# Altium schematic sheet PowerCastle.SchDoc
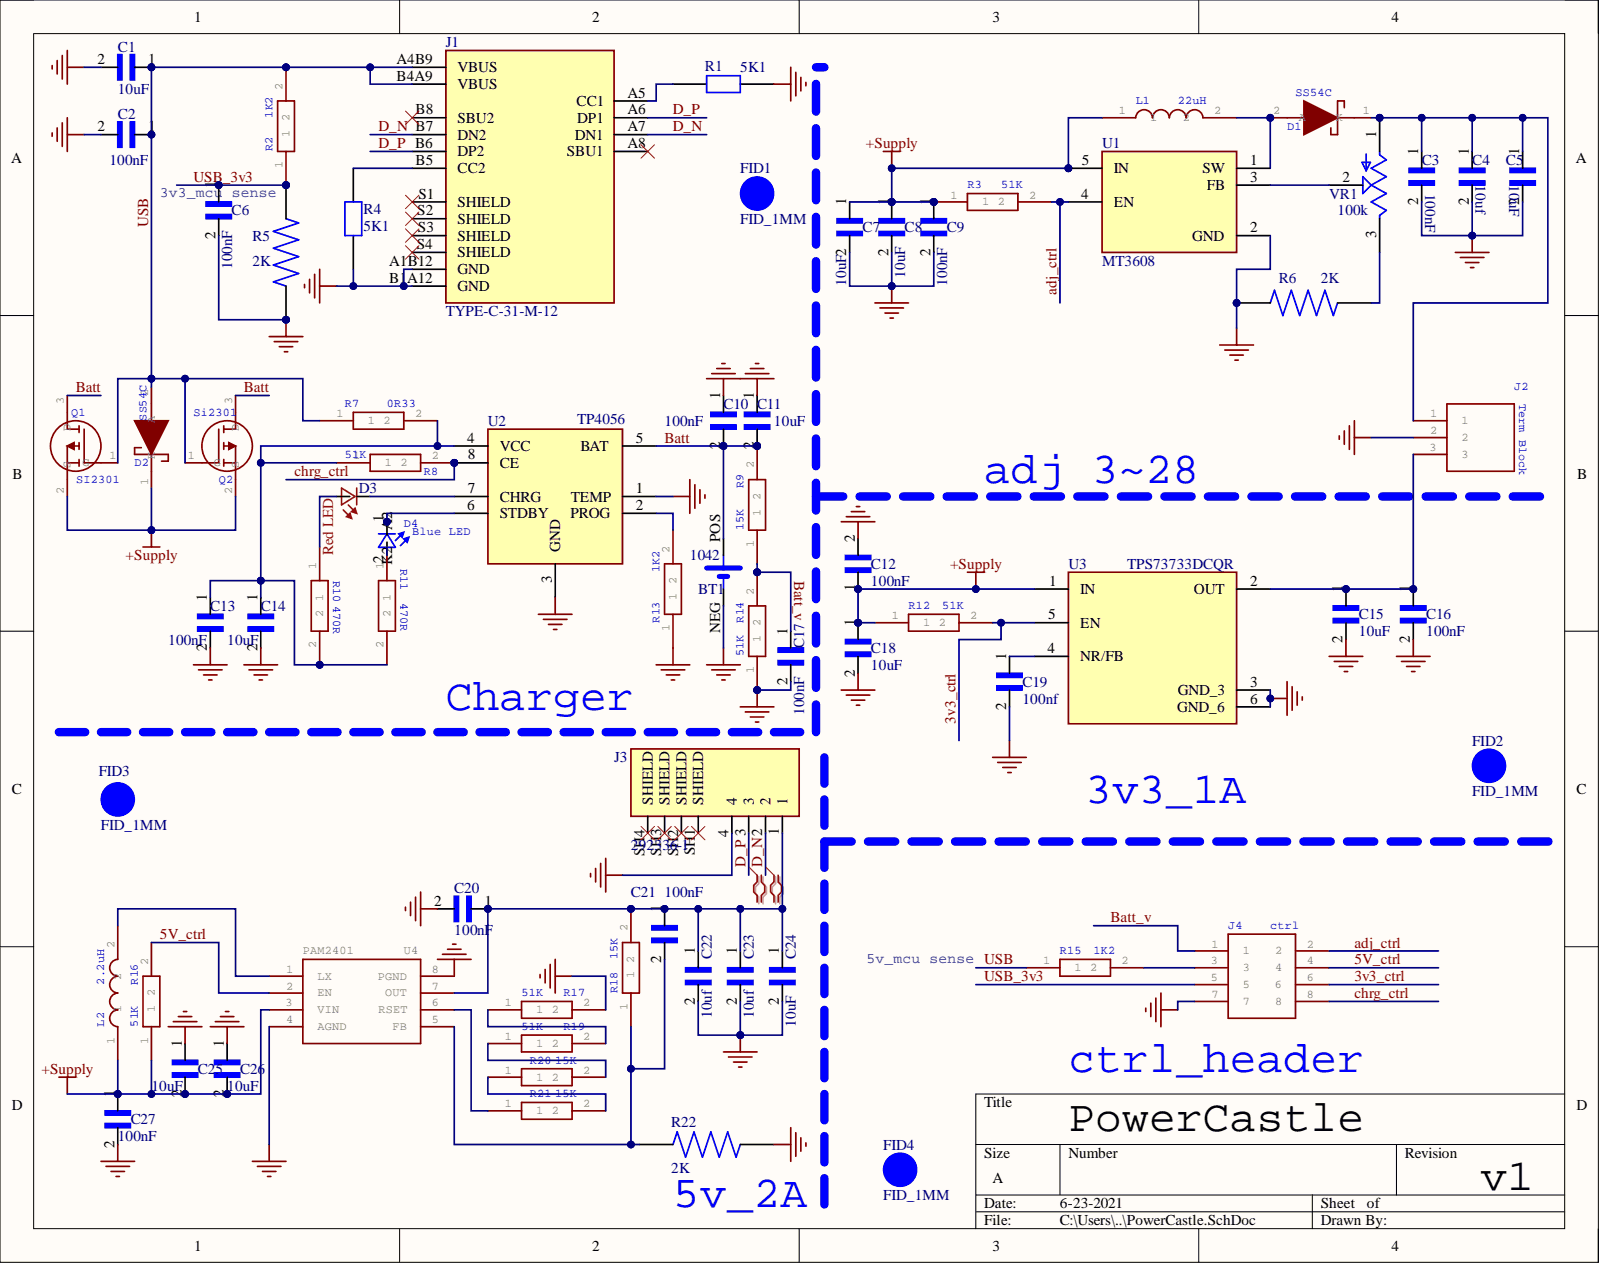
[diagram p0: PowerCastle.SchDoc]

## Parts
BT1  1042  [BAT_1042]
C1  10uF  [CAPC1608X90N]
C2  100nF  [CAPC1005X55N]
C3  100nF  [CAPC1005X55N]
C4  10uf  [CAPC1608X90N]
C5  10uF  [CAPC1608X90N]
C6  100nF  [CAPC1005X55N]
C7  10uF  [CAPC1608X90N]
C8  10uF  [CAPC1608X90N]
C9  100nF  [CAPC1005X55N]
C10  100nF  [CAPC1005X55N]
C11  10uF  [CAPC1608X90N]
C12  100nF  [CAPC1005X55N]
C13  100nF  [CAPC1005X55N]
C14  10uF  [CAPC1608X90N]
C15  10uF  [CAPC1608X90N]
C16  100nF  [CAPC1005X55N]
C17  100nF  [CAPC1005X55N]
C18  10uF  [CAPC1608X90N]
C19  100nf  [CAPC1005X55N]
C20  100nF  [CAPC1005X55N]
C21  100nF  [CAPC1005X55N]
C22  10uf  [CAPC1608X90N]
C23  10uf  [CAPC1608X90N]
C24  10uF  [CAPC1608X90N]
C25  10uF  [CAPC1608X90N]
C26  10uF  [CAPC1608X90N]
C27  100nF  [CAPC1005X55N]
D1  SS54C  [DIOM7859X239N]
D2  SS54C  [DIOM7859X239N]
D3  Red LED  [0603]
D4  Blue LED  [19217BHCZL1M2RY3T]
FID1  FID_1MM  [FID_40MM]  (no BOM)
FID2  FID_1MM  [FID_40MM]  (no BOM)
FID3  FID_1MM  [FID_40MM]  (no BOM)
FID4  FID_1MM  [FID_40MM]  (no BOM)
J1  TYPE-C-31-M-12  [HRO_TYPE-C-31-M-12]
J2  Term Block  [1711738]
J3  292336-1  [TE_292336-1]
J4  ctrl  [RHDR8W64P254_2X4_1066X500X875P]
L1  22uH  [INDPM125125X685N]
L2  2.2uH  [SLF6045T2R2N3R33PF]
Q1  SI2301  [SOT95P240X120-3N]
Q2  Si2301  [SOT95P240X120-3N]
R1  5K1  [RESC1005X40N - duplicate]
R2  1K2  [RESC1608X55N 1K2]
R3  51K  [RESC1608X55N - 51k]
R4  5K1  [RESC1005X40N - duplicate]
R5  2K  [RESC1005X40N]
R6  2K  [RESC1005X40N]
R7  0R33  [RESC3126X65N]
R8  51K  [RESC1608X55N - 51k]
R9  15K  [RESC1608X55N 15k]
R10  470R  [RESC1005X40N]
R11  470R  [RESC1005X40N]
R12  51K  [RESC1608X55N - 51k]
R13  1K2  [RESC1608X55N 1K2]
R14  51K  [RESC1608X55N - 51k]
R15  1K2  [RESC1608X55N 1K2]
R16  51K  [RESC1608X55N - 51k]
R17  51K  [RESC1608X55N - 51k]
R18  15K  [RESC1608X55N 15k]
R19  51K  [RESC1608X55N - 51k]
R20  15K  [RESC1608X55N 15k]
R21  15K  [RESC1608X55N 15k]
R22  2K  [RESC1005X40N]
U1  MT3608  [SOT95P280X145-6N]
U2  TP4056  [TP4056]
U3  TPS73733DCQR  [IC_TPS73733DCQR]
U4  PAM2401  [SOP65P490X110-8N]
VR1  100k  [TRIM_3362P-1-104]

## Nets
+Supply: C7.1 C8.1 C9.1 C12.1 C18.1 C25.2 C26.2 C27.1 D2.1 L1.1 L2.1 Q1.2 Q2.2 R3.1 R12.1 R16.1 U1.5(IN) U3.1(IN) U4.3(VIN)
GND: BT1.NEG C1.2 C2.2 C3.2 C4.2 C5.2 C6.2 C7.2 C8.2 C9.2 C10.1 C11.1 C12.2 C13.2 C14.2 C15.2 C16.2 C17.2 C18.2 C19.2 C20.2 C22.2 C23.2 C24.2 C25.1 C26.1 C27.2 J1.A1B12(GND) J1.B1A12(GND) J2.2 J3.4 J4.7 R1.1 R4.2 R5.1 R6.2 R13.1 R14.1 R17.2 R22.1 U1.2(GND) U2.1(TEMP) U2.3(GND) U3.3(GND_3) U3.6(GND_6) U4.4(AGND) U4.8(PGND)
3v3_ctrl: J4.6 R12.2 U3.5(EN)
5V_ctrl: J4.4 R16.2 U4.2(EN)
adj_ctrl: J4.2 R3.2 U1.4(EN)
Batt: BT1.POS C10.2 C11.2 Q1.3 Q2.3 R9.2 U2.5(BAT)
Batt_v: C17.1 J4.1 R9.1 R14.2
chrg_ctrl: J4.8 R8.2 U2.8(CE)
D_N: J1.A7(DN1) J1.B7(DN2) J3.2
D_P: J1.A6(DP1) J1.B6(DP2) J3.3
USB: C1.1 C2.1 D2.2 J1.A4B9(VBUS) J1.B4A9(VBUS) Q1.1 Q2.1 R2.2 R7.1 R15.1
USB_3v3: C6.1 J4.5 R2.1 R5.2
NetC3_1: C3.1 C4.1 C5.1 D1.1 J2.1 VR1.1
NetC13_1: C13.1 C14.1 R7.2 R8.1 R10.2 R11.2 U2.4(VCC)
NetC15_1: C15.1 C16.1 J2.3 U3.2(OUT)
NetC19_1: C19.1 U3.4(NR/FB)
NetC20_1: C20.1 C21.1 C22.1 C23.1 C24.1 J3.1 R18.2 U4.7(OUT)
NetC21_2: C21.2 R18.1 R22.2 U4.5(FB)
NetD1_2: D1.2 L1.2 U1.1(SW)
NetD3_1: D3.1 U2.7(CHRG)
NetD3_2: D3.2 R10.1
NetD4_1: D4.1 U2.6(STDBY)
NetD4_2: D4.2 R11.1
NetJ1_A5: J1.A5(CC1) R1.2
NetJ1_B5: J1.B5(CC2) R4.1
NetJ4_3: J4.3 R15.2
NetL2_2: L2.2 U4.1(LX)
NetR6_1: R6.1 VR1.3
NetR13_2: R13.2 U2.2(PROG)
NetR17_1: R17.1 R19.2
NetR19_1: R19.1 R20.2
NetR20_1: R20.1 R21.2
NetR21_1: R21.1 U4.6(RSET)
NetU1_3: U1.3(FB) VR1.2

Not connected: J1 A8(SBU1) B8(SBU2) S1(SHIELD) S2(SHIELD) S3(SHIELD) S4(SHIELD); J3 SH1(SHIELD) SH2(SHIELD) SH3(SHIELD) SH4(SHIELD)

## Library data of the parts
BT1 = 1042: RF EMI Absorbing Sheet Q-ZorbÂ® Silicone Rubber Non-Conductive, Single Sided 24.000 _609.60mm_ X 24.000 _609.60mm_ X 0.094 _2.40mm_; MF: Laird Technologies; Availability: Unavailable; Component Kind: Standard; MP: 1042; Package: 0402 Laird Technologies; Price: None; SnapEDA_Link: https://www.snapeda.com/parts/1042/Laird%20Technologies%20EMI/view-part/238870/?ref=snap; Library Name: PowerCastle.SchLib
C1 C4 C5 C7 C8 C11 C14 C15 C18 C22-C26 = 10uf: 10ÂµF Â±10% 10V Ceramic Capacitor X5R 0603 _1608 Metric_; MF: Samsung; MP: CL10A106KP8NNNC; Availability: Unavailable; Component Kind: Standard; LCSC Part #: C19702; Package: 0603 Samsung; Price: None; SnapEDA_Link: https://www.snapeda.com/parts/CL10A106KP8NNNC/Samsung/view-part/3598152/?ref=snap; Library Name: PowerCastle.SchLib
C2 C3 C6 C9 C10 C12 C13 C16 C17 C19-C21 C27 = 100nf: 0.1ÂµF Â±10% 16V Ceramic Capacitor X7R 0402 _1005 Metric_; MF: Samsung Electro-Mechanics; MP: CL05B104KO5NNNC; Availability: Unavailable; Component Kind: Standard; LCSC Part #: C1525; Package: 0402 Samsung; Price: None; SnapEDA_Link: https://www.snapeda.com/parts/CL05B104KO5NNNC/Samsung%20Electro-Mechanics/view-part/3598311/?ref=snap; Library Name: PowerCastle.SchLib
D1 D2 = SS54C-HF: Manufacturer_Name: Comchip Technology; GateName_1: G$1; Component Kind: Standard; DeviceSetName: SS54C-HF; Height: 2.39mm; LCSC Part #: C16103; LibraryName: SS54C-HF; Manufacturer_Part_Number: SS54C-HF; Mouser_Part_Number: 750-SS54C-HF; Mouser_Price-Stock: https://www.mouser.co.uk/ProductDetail/Comchip-Technology/SS54C-HF?qs=GBLSl2AkirvDP3hY2YYGnA%3D%3D; Package: DIOM7859X239N; SymbolName_1: SS54C-HF; Library Name: PowerCastle.SchLib
D3 = Red LED: Red 631nm LED Indication - Discrete 2V 0603 _1608 Metric_; MF: Lite-On Inc.; MP: LTST-C191KRKT; Parameter 1: C125099; Component Kind: Standard; Digi-Key_Part_Number: 160-1447-1-ND; Digi-Key_Purchase_URL: https://www.digikey.in/product-detail/en/lite-on-inc/LTST-C191KRKT/160-1447-1-ND/386836?utm_source=snapeda&utm_medium=aggregator&utm_campaign=symbol; Package: 0603 Lite-On; SnapEDA_Link: https://www.snapeda.com/parts/LTST-C191KRKT/Lite-On%20Inc./view-part/234319/?ref=snap; Library Name: PowerCastle.SchLib
D4 = Blue_LED: Manufacturer_Name: Everlight; Manufacturer_Part_Number: 19-217/BHC-ZL1M2RY/3T; DeviceSetName: 19-217_BHC-ZL1M2RY_3T; GateName_1: G$1; Component Kind: Standard; Height: 0.45mm; LCSC Part #: C72041; LibraryName: 19-217_BHC-ZL1M2RY_3T; Package: 19217BHCZL1M2RY3T; SymbolName_1: 19-217_BHC-ZL1M2RY_3T; Library Name: PowerCastle.SchLib
FID1-FID4 = FID_1MM: Fiducial 40mm diameter Mask; Library Name: PowerCastle.SchLib
J1 = TYPE-C-31-M-12: USB Connectors 24 Receptacle 1 8.94*7.3mm RoHS; MF: HRO Electronics Co., Ltd.; Availability: Unavailable; Component Kind: Standard; MP: TYPE-C-31-M-12; Package: Package; Price: None; SnapEDA_Link: https://www.snapeda.com/parts/TYPE-C-31-M-12/HRO%20Electronics%20Co.,%20Ltd./view-part/3228496/?ref=snap; Library Name: PowerCastle.SchLib
J2 = 1711738: Manufacturer_Name: Phoenix Contact; GateName_1: G$1; Arrow_Part_Number: 1711738; Arrow_Price-Stock: https://www.arrow.com/en/products/1711738/phoenix-contact; Component Kind: Standard; DeviceSetName: 1711738; Height: 18.2mm; LCSC Part #: C91156; LibraryName: 1711738; Manufacturer_Part_Number: 1711738; Mouser_Part_Number: 651-1711738; Mouser_Price-Stock: https://www.mouser.co.uk/ProductDetail/Phoenix-Contact/1711738?qs=F79NelsxgYGJoCQSSUE92w%3D%3D; Package: 1711738; SymbolName_1: 1711738; Library Name: PowerCastle.SchLib
J3 = 292336-1: Connector USB Receptacle 4 Position 2mm Solder Right Angle Thru-Hole 4 Terminal 1 Port Tube; MF: TE Connectivity; Availability: Unavailable; Component Kind: Standard; DigiKey-Purchase-URL: https://snapeda.com/shop?store=DigiKey&id=2759097; LCSC Part #: C305979; MP: 292336-1; Package: None; Price: None; SnapEDA_Link: https://www.snapeda.com/parts/292336-1/TE%20Connectivity%20AMP%20Connectors/view-part/2759097/?ref=snap; Library Name: PowerCastle.SchLib
J4 = 8POS 2mm54: A2541HWV-2X4P; Manufacturer_Name: Changjiang Connectors; GateName_1: G$1; Component Kind: Standard; DeviceSetName: A2541HWV-2X4P; Height: 8.75mm; LCSC Part #: C239344; LibraryName: A2541HWV-2x4P; Manufacturer_Part_Number: A2541HWV-2x4P; Package: RHDR8W64P254_2X4_1066X500X875P; SymbolName_1: A2541HWV-2X4P; Library Name: PowerCastle.SchLib
L1 = L?: Manufacturer_Name: TDK; DeviceSetName: SLF12565T-220M3R5-PF; GateName_1: G$1; Arrow_Part_Number: SLF12565T-220M3R5-PF; Arrow_Price-Stock: https://www.arrow.com/en/products/slf12565t-220m3r5-pf/tdk; Component Kind: Standard; Height: 6.85mm; LCSC Part #: C136214; LibraryName: SLF12565T-220M3R5-PF; Manufacturer_Part_Number: SLF12565T-220M3R5-PF; Mouser_Part_Number: 810-SLF12565T-220M; Mouser_Price-Stock: https://www.mouser.co.uk/ProductDetail/TDK/SLF12565T-220M3R5-PF?qs=8CgNHN55ycMzgjStP7MBCQ%3D%3D; Package: INDPM125125X685N; SymbolName_1: SLF12565T-220M3R5-PF; Library Name: PowerCastle.SchLib
L2 = SLF6045T-2R2N3R3-3PF: Manufacturer_Name: TDK; GateName_1: G$1; Arrow_Part_Number: SLF6045T-2R2N3R3-3PF; Arrow_Price-Stock: https://www.arrow.com/en/products/slf6045t-2r2n3r3-3pf/tdk; Component Kind: Standard; DeviceSetName: SLF6045T-2R2N3R3-3PF; Height: 4.8mm; LibraryName: SLF6045T-2R2N3R3-3PF; Manufacturer_Part_Number: SLF6045T-2R2N3R3-3PF; Mouser_Part_Number: 810-SLF645T2R2N3R3PF; Mouser_Price-Stock: https://www.mouser.co.uk/ProductDetail/TDK/SLF6045T-2R2N3R3-3PF?qs=HCSEz3Yk0hVZHYxKsI9Icg%3D%3D; Package: SLF6045T2R2N3R33PF; SymbolName_1: SLF6045T-2R2N3R3-3PF; Library Name: PowerCastle.SchLib
Q1 Q2 = SI2301: Manufacturer_Name: PUOLOP; GateName_1: G$1; Component Kind: Standard; DeviceSetName: SI2301; Height: 1.2mm; LCSC Part #: C306861; LibraryName: SI2301; Manufacturer_Part_Number: SI2301; Package: SOT95P240X120-3N; SymbolName_1: SI2301; Library Name: PowerCastle.SchLib
R1 R4 = 5K1: RES, THICK FILM, 5K1, 5%, 0.0625W, 0402; MP: RC0402JR-075K1L; Availability: Unavailable; Component Kind: Standard; Package: None; Price: None; Library Name: PowerCastle.SchLib
R2 R13 R15 = 1K2: 0603WAF1201T5E; Manufacturer_Name: UNI-ROYAL(Uniroyal Elec); GateName_1: G$1; Component Kind: Standard; DeviceSetName: 0603WAF1201T5E; Height: 0.55mm; LibraryName: 0603WAF1201T5E; Manufacturer_Part_Number: 0603WAF1201T5E; Package: RESC1608X55N; SymbolName_1: 0603WAF1201T5E; Library Name: PowerCastle.SchLib
R3 R12 R14 R16 R17 R19 = 51K: Manufacturer_Name: YAGEO (PHYCOMP); Manufacturer_Part_Number: RC0603FR-0751KL; DeviceSetName: RC0603FR-0715KL; GateName_1: G$1; Parameter 1: C114661; Component Kind: Standard; Height: 0.55mm; LibraryName: RC0603FR-0715KL; Mouser_Part_Number: 603-RC0603FR-0715KL; Mouser_Price-Stock: https://www.mouser.co.uk/ProductDetail/Yageo/RC0603FR-0715KL?qs=VU8sRB4EgwBhsSCK0A%252BW%252Bw%3D%3D; Package: RESC1608X55N; SymbolName_1: RC0603FR-0715KL; Library Name: PowerCastle.SchLib
R5 R6 R22 = 2K: AC Series 0402 0.063 W 2 kOhm Â±1% Â±100 ppm/Â°C SMT Thick Film Chip Resistor; MP: AC0402FR-072KL; Availability: Unavailable; Component Kind: Standard; LCSC Part #: C144770; Package: None; Price: None; Library Name: PowerCastle.SchLib
R7 = 0R33: Manufacturer_Name: UNI-ROYAL(Uniroyal Elec); DeviceSetName: 1210W2F330LT5E; GateName_1: G$1; Component Kind: Standard; Height: 0.65mm; LCSC Part #: C95211; LibraryName: 1210W2F330LT5E; Manufacturer_Part_Number: 1210W2F330LT5E; Package: RESC3126X65N; SymbolName_1: 1210W2F330LT5E; Library Name: PowerCastle.SchLib
R8 = 51K: RC0603FR-0751KL; Manufacturer_Name: YAGEO (PHYCOMP); DeviceSetName: RC0603FR-0715KL; GateName_1: G$1; Parameter 1: C114661; Component Kind: Standard; Height: 0.55mm; LibraryName: RC0603FR-0715KL; Manufacturer_Part_Number: RC0603FR-0751KL; Mouser_Part_Number: 603-RC0603FR-0715KL; Mouser_Price-Stock: https://www.mouser.co.uk/ProductDetail/Yageo/RC0603FR-0715KL?qs=VU8sRB4EgwBhsSCK0A%252BW%252Bw%3D%3D; Package: RESC1608X55N; SymbolName_1: RC0603FR-0715KL; Library Name: PowerCastle.SchLib
R9 R18 R20 R21 = 15K: Manufacturer_Name: YAGEO (PHYCOMP); DeviceSetName: RC0603FR-0715KL; GateName_1: G$1; Parameter 1: C114661; Component Kind: Standard; Height: 0.55mm; LibraryName: RC0603FR-0715KL; Manufacturer_Part_Number: RC0603FR-0715KL; Mouser_Part_Number: 603-RC0603FR-0715KL; Mouser_Price-Stock: https://www.mouser.co.uk/ProductDetail/Yageo/RC0603FR-0715KL?qs=VU8sRB4EgwBhsSCK0A%252BW%252Bw%3D%3D; Package: RESC1608X55N; SymbolName_1: RC0603FR-0715KL; Library Name: PowerCastle.SchLib
R10 R11 = 470R: Manufacturer_Name: Uniroyal Elec; DeviceSetName: 0402WGF4700TCE; GateName_1: G$1; Component Kind: Standard; Height: 0.4mm; LCSC Part #: C25117; LibraryName: 0402WGF4700TCE; Manufacturer_Part_Number: 0402WGF4700TCE; Package: RESC1005X40N; SymbolName_1: 0402WGF4700TCE; Library Name: PowerCastle.SchLib
U1 = USB_5V: High Efficiency 1.2MHz2A Step Up Converter; MF: Aerosemi Technology Co., Ltd; Availability: Unavailable; Component Kind: Standard; LCSC Part #: C144770; MP: MT3608; Package: Package Analog Devices; Price: None; SnapEDA_Link: https://www.snapeda.com/parts/MT3608/Aerosemi%20Technology%20Co.%2C%20Ltd/view-part/3218014/?ref=snap; Library Name: PowerCastle.SchLib
U2 = TP4056: Complete single cell Li-Ion battery with a constant current / constant voltage linear charger; MF: NanJing Top Power ASIC Corp.; Availability: Unavailable; Component Kind: Standard; LCSC Part #: C16581; MP: TP4056; Package: Package Analog Devices; Price: None; SnapEDA_Link: https://www.snapeda.com/parts/TP4056%20/NanJing%20Top%20Power%20ASIC%20Corp./view-part/2888426/?ref=snap; Library Name: PowerCastle.SchLib
U3 = TPS73733DCQR: 1-A, ultra-low-dropout voltage regulator with reverse current protection & enable 6-SOT-223 -40 to 125; MF: Texas Instruments; Availability: Warning; Component Kind: Standard; MP: TPS73733DCQR; Package: SOT-223-6 Texas Instruments; Price: None; Purchase-URL: https://pricing.snapeda.com/search/part/TPS73733DCQR/?ref=eda; SnapEDA_Link: https://www.snapeda.com/parts/TPS73733DCQR/Texas%20Instruments/view-part/490350/?ref=snap; Library Name: PowerCastle.SchLib
U4 = PAM2401SCADJ: Manufacturer_Name: Diodes Inc.; GateName_1: G$1; Arrow_Part_Number: PAM2401SCADJ; Arrow_Price-Stock: https://www.arrow.com/en/products/pam2401scadj/diodes-incorporated; Component Kind: Standard; DeviceSetName: PAM2401SCADJ; Height: 1.1mm; LibraryName: PAM2401SCADJ; Manufacturer_Part_Number: PAM2401SCADJ; Mouser_Part_Number: 621-PAM2401SCADJ; Mouser_Price-Stock: https://www.mouser.co.uk/ProductDetail/Diodes-Incorporated/PAM2401SCADJ?qs=Ay79KZaUeZCprHtLIDXq%252BQ%3D%3D; Package: SOP65P490X110-8N; SymbolName_1: PAM2401SCADJ; Library Name: PowerCastle.SchLib
VR1 = 3362P-1-104: 100 kOhms 0.5W, 1/2W PC Pins Through Hole Trimmer Potentiometer Cermet 1 Turn Top Adjustment; MF: Bourns; Availability: Warning; Check_prices: https://www.snapeda.com/parts/3362P-1-104/Bourns/view-part/547461/?ref=eda; Component Kind: Standard; MP: 3362P-1-104; Package: 2220 Bourns; Price: None; Purchase-URL: https://pricing.snapeda.com/search/part/3362P-1-104/?ref=eda; SnapEDA_Link: https://www.snapeda.com/parts/3362P-1-104/Bourns/view-part/547461/?ref=snap; Library Name: PowerCastle.SchLib
